# Altium schematic sheet POWER.SchDoc
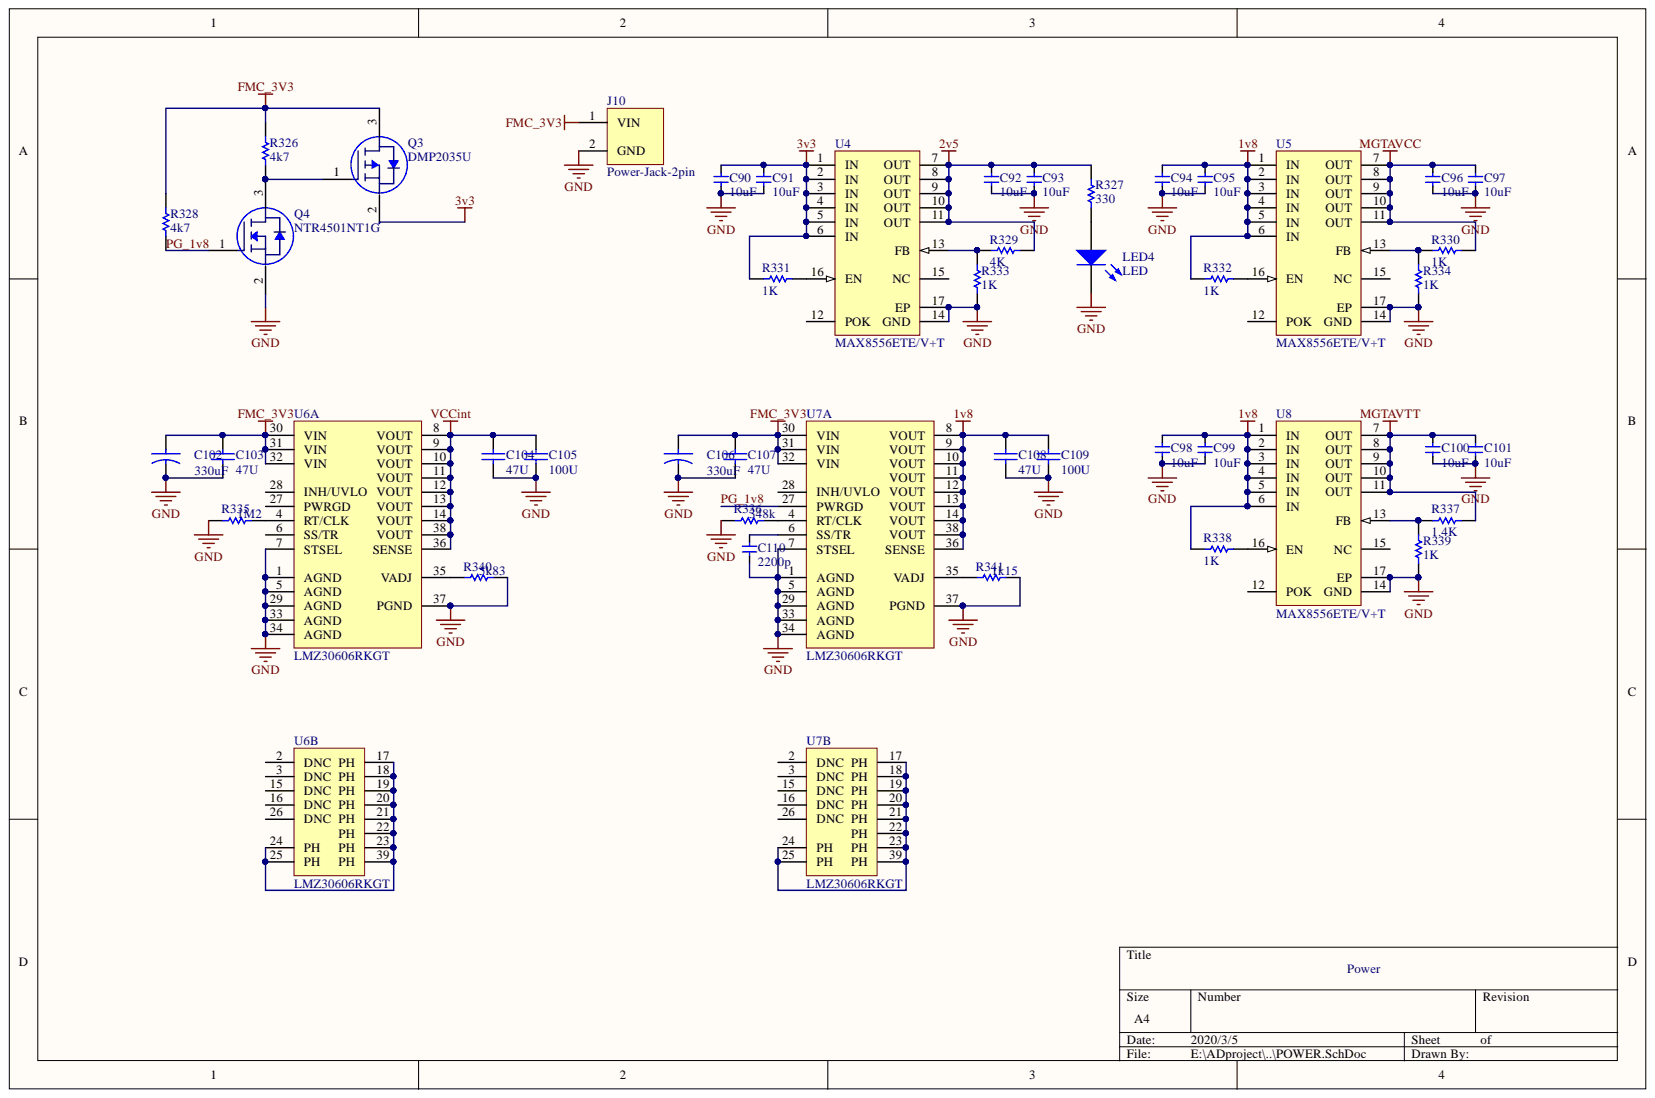
[diagram p0: POWER.SchDoc]

## Parts
C90  value 10uF  [0805]
C91  value 10uF  [0805]
C92  value 10uF  [0805]
C93  value 10uF  [0805]
C94  value 10uF  [0805]
C95  value 10uF  [0805]
C96  value 10uF  [0805]
C97  value 10uF  [0805]
C98  value 10uF  [0805]
C99  value 10uF  [0805]
C100  value 10uF  [0805]
C101  value 10uF  [0805]
C102  value 330uF  [cap2917]  (hidden comment: T520V337M2R5ATE025)
C103  value 47U  [C1210]  (hidden comment: GRM32ER70J476ME20L)
C104  value 47U  [C1210]  (hidden comment: GRM32ER70J476ME20L)
C105  value 100U  [C1210]  (hidden comment: GRM32ER60J107ME20L)
C106  value 330uF  [cap2917]  (hidden comment: T520V337M2R5ATE025)
C107  value 47U  [C1210]  (hidden comment: GRM32ER70J476ME20L)
C108  value 47U  [C1210]  (hidden comment: GRM32ER70J476ME20L)
C109  value 100U  [C1210]  (hidden comment: GRM32ER60J107ME20L)
C110  value 2200p  [0402]
J10  Power-Jack-2pin  [power-jack-2pin]
LED4  LED  [led_0603r]
Q3  DMP2035U  [sot-23]
Q4  NTR4501NT1G  [sot-23]
R326  value 4k7  [RESC0603(1608)_M]
R327  value 330  [0402]
R328  value 4k7  [RESC0603(1608)_M]
R329  value 4K  [RESC0603(1608)_M]
R330  value 1K  [RESC0603(1608)_M]
R331  value 1K  [RESC0603(1608)_M]
R332  value 1K  [RESC0603(1608)_M]
R333  value 1K  [RESC0603(1608)_M]
R334  value 1K  [RESC0603(1608)_M]
R335  value 1M2  [RESC0603(1608)_N]
R336  value 348k  [RESC0603(1608)_N]
R337  value 1.4K  [RESC0603(1608)_M]
R338  value 1K  [RESC0603(1608)_M]
R339  value 1K  [RESC0603(1608)_M]
R340  value 5k83  [RESC0603(1608)_N]
R341  value 1k15  [RESC0603(1608)_N]
U4  MAX8556ETE/V+T  [T1655+3_M]
U5  MAX8556ETE/V+T  [T1655+3_M]
U6  LMZ30606RKGT  [qfn-n39]  (parts A,B)
U7  LMZ30606RKGT  [qfn-n39]  (parts A,B)
U8  MAX8556ETE/V+T  [T1655+3_M]

## Nets
1v8: C94.2 C95.2 C98.2 C99.2 C108.2 C109.2 R332.1 R338.1 U5.1(IN) U5.2(IN) U5.3(IN) U5.4(IN) U5.5(IN) U5.6(IN) U7.8(VOUT) U7.9(VOUT) U7.10(VOUT) U7.11(VOUT) U7.12(VOUT) U7.13(VOUT) U7.14(VOUT) U7.36(SENSE) U7.38(VOUT) U8.1(IN) U8.2(IN) U8.3(IN) U8.4(IN) U8.5(IN) U8.6(IN)
2v5: C92.2 C93.2 R327.1 R329.2 U4.7(OUT) U4.8(OUT) U4.9(OUT) U4.10(OUT) U4.11(OUT)
3v3: C90.2 C91.2 Q3.2 R331.1 U4.1(IN) U4.2(IN) U4.3(IN) U4.4(IN) U4.5(IN) U4.6(IN)
FMC_3V3: C102.1 C103.2 C106.1 C107.2 J10.1 Q3.3 R326.2 R328.2 U6.30(VIN) U6.31(VIN) U6.32(VIN) U7.30(VIN) U7.31(VIN) U7.32(VIN)
GND: C90.1 C91.1 C92.1 C93.1 C94.1 C95.1 C96.1 C97.1 C98.1 C99.1 C100.1 C101.1 C102.2 C103.1 C104.1 C105.1 C106.2 C107.1 C108.1 C109.1 C110.1 J10.2 LED4.1 Q4.2 R333.1 R334.1 R335.1 R336.1 R339.1 R340.2 R341.2 U4.14(GND) U4.17(EP) U5.14(GND) U5.17(EP) U6.1(AGND) U6.5(AGND) U6.7(STSEL) U6.29(AGND) U6.33(AGND) U6.34(AGND) U6.37(PGND) U7.1(AGND) U7.5(AGND) U7.7(STSEL) U7.29(AGND) U7.33(AGND) U7.34(AGND) U7.37(PGND) U8.14(GND) U8.17(EP)
MGTAVCC: C96.2 C97.2 R330.2 U5.7(OUT) U5.8(OUT) U5.9(OUT) U5.10(OUT) U5.11(OUT)
MGTAVTT: C100.2 C101.2 R337.2 U8.7(OUT) U8.8(OUT) U8.9(OUT) U8.10(OUT) U8.11(OUT)
VCCint: C104.2 C105.2 U6.8(VOUT) U6.9(VOUT) U6.10(VOUT) U6.11(VOUT) U6.12(VOUT) U6.13(VOUT) U6.14(VOUT) U6.36(SENSE) U6.38(VOUT)
PG_1v8: Q4.1 R328.1 U7.27(PWRGD)
NetC110_2: C110.2 U7.6(SS/TR)
NetLED4_2: LED4.2 R327.2
NetQ3_1: Q3.1 Q4.3 R326.1
NetR329_1: R329.1 R333.2 U4.13(FB)
NetR330_1: R330.1 R334.2 U5.13(FB)
NetR331_2: R331.2 U4.16(EN)
NetR332_2: R332.2 U5.16(EN)
NetR335_2: R335.2 U6.4(RT/CLK)
NetR336_2: R336.2 U7.4(RT/CLK)
NetR337_1: R337.1 R339.2 U8.13(FB)
NetR338_2: R338.2 U8.16(EN)
NetR340_1: R340.1 U6.35(VADJ)
NetR341_1: R341.1 U7.35(VADJ)
NetU6_17: U6.17(PH) U6.18(PH) U6.19(PH) U6.20(PH) U6.21(PH) U6.22(PH) U6.23(PH) U6.24(PH) U6.25(PH) U6.39(PH)
NetU7_17: U7.17(PH) U7.18(PH) U7.19(PH) U7.20(PH) U7.21(PH) U7.22(PH) U7.23(PH) U7.24(PH) U7.25(PH) U7.39(PH)

Not connected: U4 12(POK) 15(NC); U5 12(POK) 15(NC); U6 2(DNC) 3(DNC) 6(SS/TR) 15(DNC) 16(DNC) 26(DNC) 27(PWRGD) 28(INH/UVLO); U7 2(DNC) 3(DNC) 15(DNC) 16(DNC) 26(DNC) 28(INH/UVLO); U8 12(POK) 15(NC)

## Library data of the parts
C90-C101 C110 = CapSMT: Capacitor (Surface Mount); Component Kind: Standard; Signal Integrity: Cap; Simulation: CAP; Publisher: Altium Limited
  C90-C101: Library Name: general.SCHLIB
  C110: Library Name: LS1_full_size.SCHLIB
C102 C106 = Cap_pol: Component Kind: Standard; Library Name: general.SchLib
C103-C105 C107-C109 = Cap Semi: Capacitor (Semiconductor SIM Model); Component Kind: Standard; Library Name: general.SCHLIB; PackageReference: C1206; Signal Integrity: Cap Semi; Simulation: CAP; Publisher: Altium Limited
Q3 = P-MOSFET: Component Kind: Standard; Library Name: general.SchLib
Q4 = N-MOSFET: Component Kind: Standard; Library Name: general.SchLib
R326 R328-R334 R337-R339 = CMP-1012-00213-1: Case-EIA: 0603; Case-Metric: 1608; Power: 0.1 W; Tolerance: 1 %; Component Kind: Standard; Library Name: Altium Content Vault; Technology: SMT
R327 R335 R336 R340 R341 = CMP-1012-00454-1: Case-EIA: 0603; Case-Metric: 1608; Power: 0.1 W; Tolerance: 1 %; Component Kind: Standard; Library Name: Altium Content Vault; Technology: SMT
U4 U5 U8 = CMP-0246-00124-1: 4A Ultra-Low-Input-Voltage LDO Regulator, Automotive Qualified, 16-Pin QFN-EP, -40°C to +85°C, Pb-Free, Tape and Reel; Code_JEDEC: MO-220WHHB; Component Kind: Standard; ComponentLink1Description: Manufacturer URL; ComponentLink1URL: http://www.maxim-ic.com; ComponentLink2Description: Datasheet; ComponentLink2URL: http://datasheets.maxim-ic.com/en/ds/MAX8556-MAX8557.pdf; ComponentLink3Description: Package Specification; ComponentLink3URL: http://pdfserv.maxim-ic.com/package_dwgs/21-0140.PDF; DatasheetVersion: Rev 2, Aug-2009; Library Name: Altium Content Vault; PackageDescription: 16-Pin Thin Quad Flatpack, No Leads, 5 x 5 x 0.75 mm Body, 0.8 mm Pitch, TQFN, Pb-Free; PackageReference: T1655+3; PackageVersion: revO, 2011
U6 U7 = LMZ30606: Component Kind: Standard; Library Name: general.SchLib
J10 = Power-Jack-2pin: Component Kind: Standard; Library Name: general.SchLib
LED4 = LED: Component Kind: Standard; Library Name: general.SchLib
